# Altium schematic sheet Nav_Ducer_IO.SchDoc
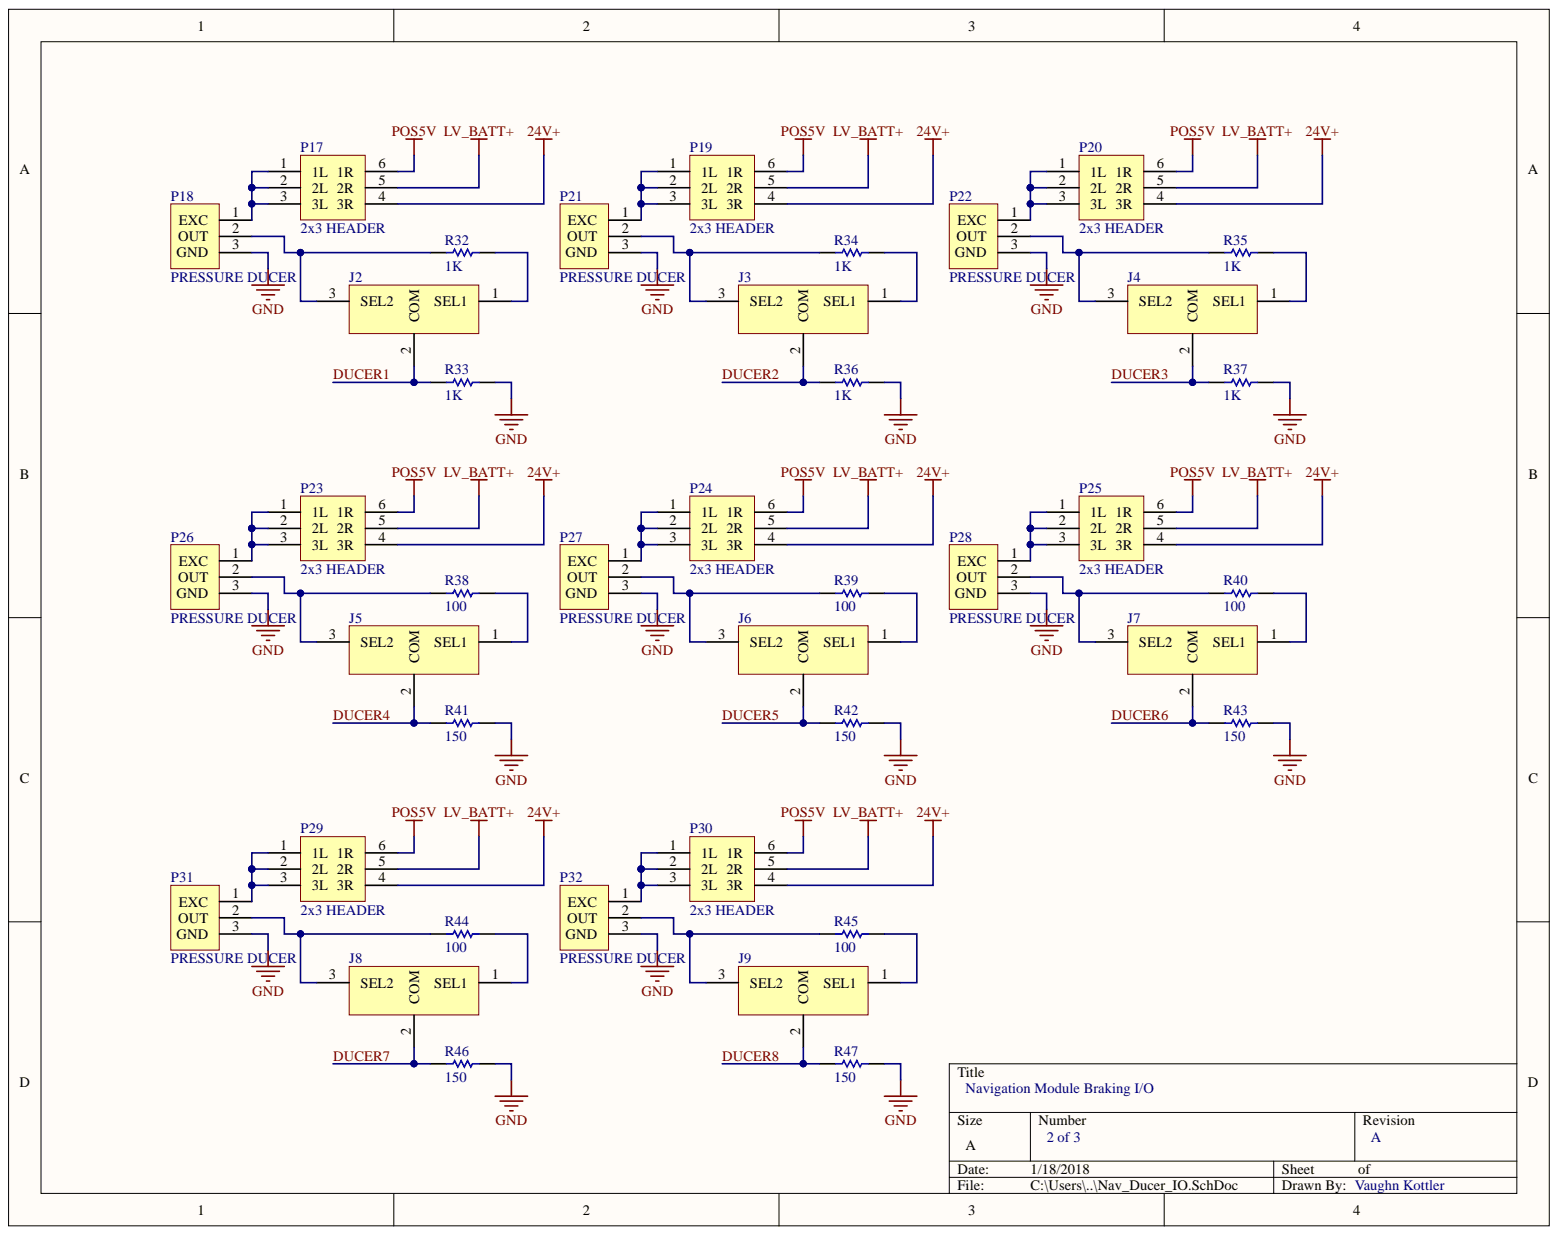
[diagram p0: Nav_Ducer_IO.SchDoc]

## Parts
J2  SINGLE_SEL  [SEL]  (value not drawn)
J3  SINGLE_SEL  [SEL]  (value not drawn)
J4  SINGLE_SEL  [SEL]  (value not drawn)
J5  SINGLE_SEL  [SEL]  (value not drawn)
J6  SINGLE_SEL  [SEL]  (value not drawn)
J7  SINGLE_SEL  [SEL]  (value not drawn)
J8  SINGLE_SEL  [SEL]  (value not drawn)
J9  SINGLE_SEL  [SEL]  (value not drawn)
P17  2x3 HEADER  [DIP6]
P18  PRESSURE DUCER  [3POS TERM BLOCK]
P19  2x3 HEADER  [DIP6]
P20  2x3 HEADER  [DIP6]
P21  PRESSURE DUCER  [3POS TERM BLOCK]
P22  PRESSURE DUCER  [3POS TERM BLOCK]
P23  2x3 HEADER  [DIP6]
P24  2x3 HEADER  [DIP6]
P25  2x3 HEADER  [DIP6]
P26  PRESSURE DUCER  [3POS TERM BLOCK]
P27  PRESSURE DUCER  [3POS TERM BLOCK]
P28  PRESSURE DUCER  [3POS TERM BLOCK]
P29  2x3 HEADER  [DIP6]
P30  2x3 HEADER  [DIP6]
P31  PRESSURE DUCER  [3POS TERM BLOCK]
P32  PRESSURE DUCER  [3POS TERM BLOCK]
R32  value 1K  [6-0805_M]  (hidden comment: Res1)
R33  value 1K  [6-0805_M]  (hidden comment: Res1)
R34  value 1K  [6-0805_M]  (hidden comment: Res1)
R35  value 1K  [6-0805_M]  (hidden comment: Res1)
R36  value 1K  [6-0805_M]  (hidden comment: Res1)
R37  value 1K  [6-0805_M]  (hidden comment: Res1)
R38  value 100  [6-0805_M]  (hidden comment: Res1)
R39  value 100  [6-0805_M]  (hidden comment: Res1)
R40  value 100  [6-0805_M]  (hidden comment: Res1)
R41  value 150  [6-0805_M]  (hidden comment: Res1)
R42  value 150  [6-0805_M]  (hidden comment: Res1)
R43  value 150  [6-0805_M]  (hidden comment: Res1)
R44  value 100  [6-0805_M]  (hidden comment: Res1)
R45  value 100  [6-0805_M]  (hidden comment: Res1)
R46  value 150  [6-0805_M]  (hidden comment: Res1)
R47  value 150  [6-0805_M]  (hidden comment: Res1)

## Nets
24V+: P17.4(3R) P19.4(3R) P20.4(3R) P23.4(3R) P24.4(3R) P25.4(3R) P29.4(3R) P30.4(3R)
GND: P18.3 P21.3 P22.3 P26.3 P27.3 P28.3 P31.3 P32.3 R33.1 R36.1 R37.1 R41.1 R42.1 R43.1 R46.1 R47.1
LV_BATT+: P17.5(2R) P19.5(2R) P20.5(2R) P23.5(2R) P24.5(2R) P25.5(2R) P29.5(2R) P30.5(2R)
POS5V: P17.6(1R) P19.6(1R) P20.6(1R) P23.6(1R) P24.6(1R) P25.6(1R) P29.6(1R) P30.6(1R)
DUCER1: J2.2 R33.2
DUCER2: J3.2 R36.2
DUCER3: J4.2 R37.2
DUCER4: J5.2 R41.2
DUCER5: J6.2 R42.2
DUCER6: J7.2 R43.2
DUCER7: J8.2 R46.2
DUCER8: J9.2 R47.2
NetJ2_1: J2.1 R32.1
NetJ2_3: J2.3 P18.2 R32.2
NetJ3_1: J3.1 R34.1
NetJ3_3: J3.3 P21.2 R34.2
NetJ4_1: J4.1 R35.1
NetJ4_3: J4.3 P22.2 R35.2
NetJ5_1: J5.1 R38.1
NetJ5_3: J5.3 P26.2 R38.2
NetJ6_1: J6.1 R39.1
NetJ6_3: J6.3 P27.2 R39.2
NetJ7_1: J7.1 R40.1
NetJ7_3: J7.3 P28.2 R40.2
NetJ8_1: J8.1 R44.1
NetJ8_3: J8.3 P31.2 R44.2
NetJ9_1: J9.1 R45.1
NetJ9_3: J9.3 P32.2 R45.2
NetP17_1: P17.1(1L) P17.2(2L) P17.3(3L) P18.1
NetP19_1: P19.1(1L) P19.2(2L) P19.3(3L) P21.1
NetP20_1: P20.1(1L) P20.2(2L) P20.3(3L) P22.1
NetP23_1: P23.1(1L) P23.2(2L) P23.3(3L) P26.1
NetP24_1: P24.1(1L) P24.2(2L) P24.3(3L) P27.1
NetP25_1: P25.1(1L) P25.2(2L) P25.3(3L) P28.1
NetP29_1: P29.1(1L) P29.2(2L) P29.3(3L) P31.1
NetP30_1: P30.1(1L) P30.2(2L) P30.3(3L) P32.1

## Library data of the parts
R32-R47 = Res1: Resistor; Component Kind: Standard; LatestRevisionDate: 17-Jul-2002; LatestRevisionNote: Re-released for DXP Platform.; Library Name: Miscellaneous Devices.IntLib; PackageDescription: Axial Device, Thru-Hole; 2 Leads; 0.3 in Pin Spacing; PackageReference: AXIAL-0.3; Published: 8-Jun-2000; Signal Integrity: Res; Simulation: RESISTOR; Publisher: Altium Limited
J2-J9 = SINGLE_SEL: Component Kind: Standard; Library Name: connector.SchLib
P17 P19 P20 P23-P25 P29 P30 = 2x3 HEADER: Component Kind: Standard; Library Name: connector.SchLib
P18 P21 P22 P26-P28 P31 P32 = PRESSURE DUCER: Component Kind: Standard; Library Name: connector.SchLib
